# Altium schematic sheet Sheet1.SchDoc(Sheet1)
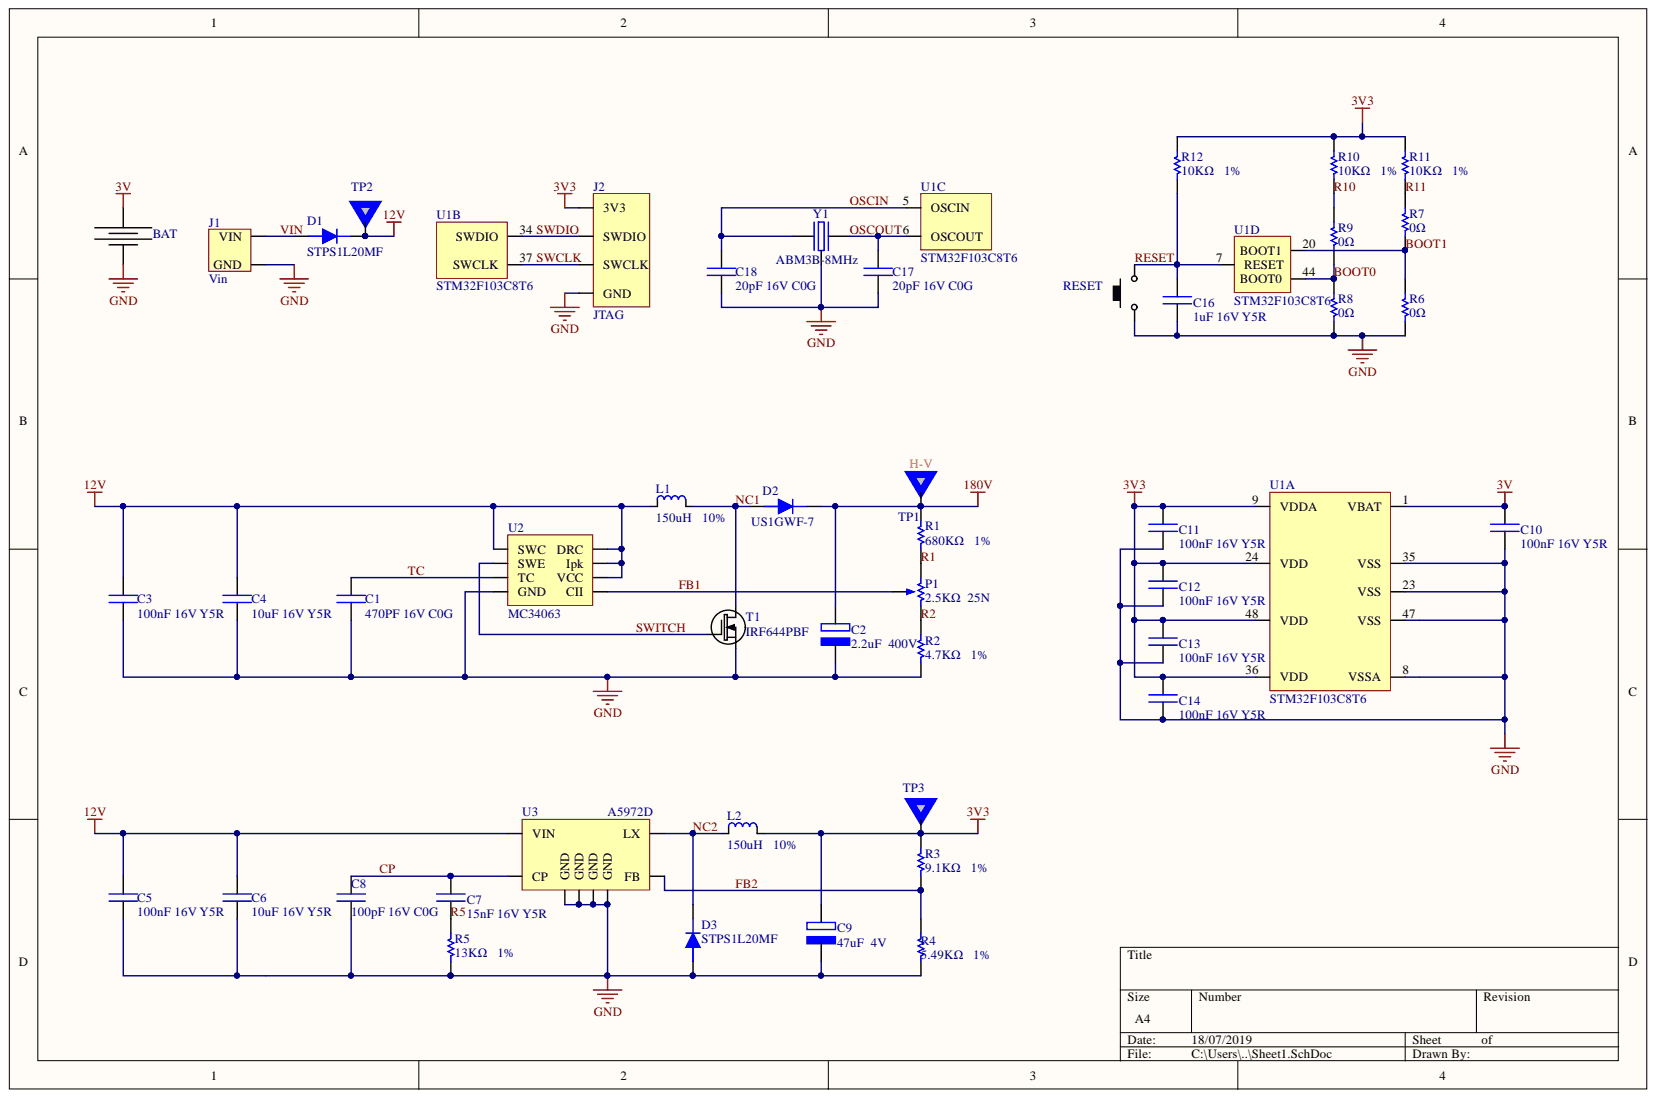
[diagram p0: Sheet1.SchDoc]

## Parts
BAT  3V  [HOLDER-2032]
C1  470PF 16V C0G  [0603]
C2  2.2uF  400V  [CAP-SIZE-F]
C3  100nF 16V Y5R  [0603]
C4  10uF 16V Y5R  [0603]
C5  100nF 16V Y5R  [0603]
C6  10uF 16V Y5R  [0603]
C7  15nF 16V Y5R  [0603]
C8  100pF 16V C0G  [0603]
C9  47uF  4V  [AVX-B-CASE]
C10  100nF 16V Y5R  [0603]
C11  100nF 16V Y5R  [0603]
C12  100nF 16V Y5R  [0603]
C13  100nF 16V Y5R  [0603]
C14  100nF 16V Y5R  [0603]
C16  1uF 16V Y5R  [0603]
C17  20pF 16V C0G  [0603]
C18  20pF 16V C0G  [0603]
D1  STPS1L20MF  [DO-222AA]
D2  US1GWF-7  [SOD-123F]
D3  STPS1L20MF  [DO-222AA]
J1  Vin  [BORNERAx2]
J2  JTAG  [PIN-HEADERx4]
L1  150uH   10%  [SRR1240]
L2  150uH   10%  [SRR1240]
P1  2.5KΩ  25N  [3296W]
R1  680KΩ   1%  [0603]
R2  4.7KΩ   1%  [0603]
R3  9.1KΩ   1%  [0603]
R4  5.49KΩ   1%  [0603]
R5  13KΩ   1%  [0603]
R6  0Ω  [0603]
R7  0Ω  [0603]
R8  0Ω  [0603]
R9  0Ω  [0603]
R10  10KΩ   1%  [0603]
R11  10KΩ   1%  [0603]
R12  10KΩ   1%  [0603]
RESET  BUTTON  [FSMSM]  (value not drawn)
T1  IRF644PBF  [TO263-3S]
TP1  HV-TEST-POINT  [Test_Point_HV]  (value not drawn)
TP2  TEST-POINT  [Test_Point]  (value not drawn)
TP3  TEST-POINT  [Test_Point]  (value not drawn)
U1  STM32F103C8T6  [LQFP48]  (parts A,B,C,D)
U2  MC34063  [SOIC-8]
U3  A5972D  [SOIC-8]
Y1  ABM3B-8MHz  [ABM3B]

## Nets
3V: BAT.1 C10.1 U1.1(VBAT)
3V3: C9.2 C11.1 C12.1 C13.1 C14.1 J2.1(3V3) L2.2 R3.2 R10.2 R11.2 R12.2 TP3.1 U1.9(VDDA) U1.24(VDD) U1.36(VDD) U1.48(VDD)
12V: C3.2 C4.2 C5.2 C6.2 D1.2 L1.1 TP2.1 U2.1(SWC) U2.6(VCC) U2.7(Ipk) U2.8(DRC) U3.8(VIN)
180V: C2.2 D2.2 R1.2 TP1.1
GND: BAT.2 C1.2 C2.1 C3.1 C4.1 C5.1 C6.1 C8.1 C9.1 C10.2 C11.2 C12.2 C13.2 C14.2 C16.1 C17.1 C18.1 D3.1 J1.2 J2.4(GND) R2.2 R4.2 R5.1 R6.1 R8.1 RESET.2 T1.2 U1.8(VSSA) U1.23(VSS) U1.35(VSS) U1.47(VSS) U2.4(GND) U3.2(GND) U3.3(GND) U3.6(GND) U3.7(GND) Y1.3
BOOT0: R8.2 R9.1 U1.44(BOOT0)
BOOT1: R6.2 R7.1 U1.20(BOOT1)
CP: C7.2 C8.2 U3.4(CP)
FB1: P1.2 U2.5(CII)
FB2: R3.1 R4.1 U3.5(FB)
NC1: D2.1 L1.2 T1.3
NC2: D3.2 L2.1 U3.1(LX)
OSCIN: C18.2 U1.5(OSCIN) Y1.1
OSCOUT: C17.2 U1.6(OSCOUT) Y1.2
R1: P1.3 R1.1
R2: P1.1 R2.1
R5: C7.1 R5.2
R10: R9.2 R10.1
R11: R7.2 R11.1
RESET: C16.2 R12.1 RESET.1 U1.7(RESET)
SWCLK: J2.3(SWCLK) U1.37(SWCLK)
SWDIO: J2.2(SWDIO) U1.34(SWDIO)
SWITCH: T1.1 U2.2(SWE)
TC: C1.1 U2.3(TC)
VIN: D1.1 J1.1

## Library data of the parts
BAT = BAT: Component Kind: Standard; Library Name: Nixielib.SchLib
C1 C3-C8 C10-C14 C16-C18 = CAP: Component Kind: Standard; Library Name: Nixielib.SchLib
C2 C9 = CAP_pol: Component Kind: Standard; Library Name: Nixielib.SchLib
D1-D3 = DIO: Component Kind: Standard; Library Name: Nixielib.SchLib
L1 L2 = IND: Component Kind: Standard; Library Name: Nixielib.SchLib
P1 = POTE: Component Kind: Standard; Library Name: Nixielib.SchLib
R1-R12 = RES: Component Kind: Standard; Library Name: Nixielib.SchLib
T1 = N-MOS: Component Kind: Standard; Library Name: Nixielib.SchLib
Y1 = Cristal: Component Kind: Standard; Library Name: Nixielib.SchLib
J1 = Vin: Component Kind: Standard; Library Name: Nixielib.SchLib
J2 = JTAG: Component Kind: Standard; Library Name: Nixielib.SchLib
RESET = BUTTON: Component Kind: Standard; Library Name: Nixielib.SchLib
TP1 = HV-TEST-POINT: Component Kind: Standard; Library Name: Nixielib.SchLib
TP2 TP3 = TEST-POINT: Component Kind: Standard; Library Name: Nixielib.SchLib
U1 = STM32F103C8T6: Component Kind: Standard; Library Name: Nixielib.SchLib
U2 = MC34063: Component Kind: Standard; Library Name: Nixielib.SchLib
U3 = A5972D: Component Kind: Standard; Library Name: Nixielib.SchLib
